# Altium schematic sheet SW6208+A+A+B+LED_普通188数码管.SchDoc
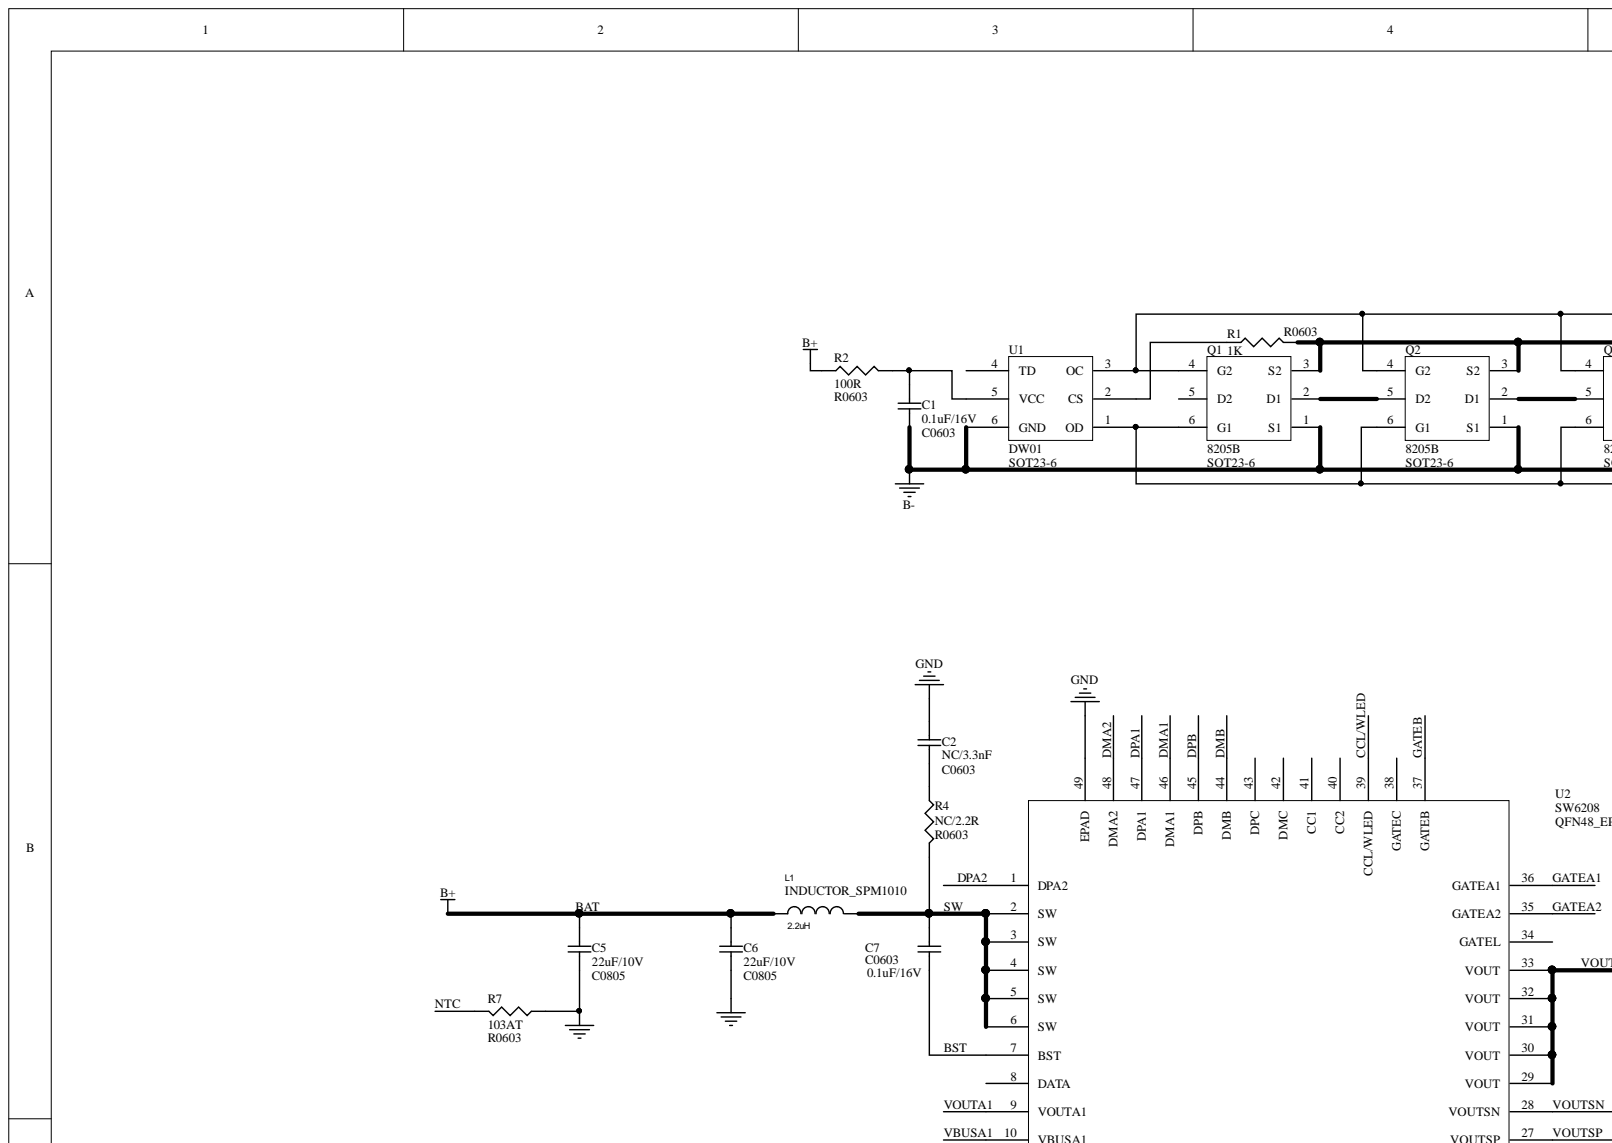
[diagram p0: SW6208+A+A+B+LED_普通188数码管.SchDoc, top left]
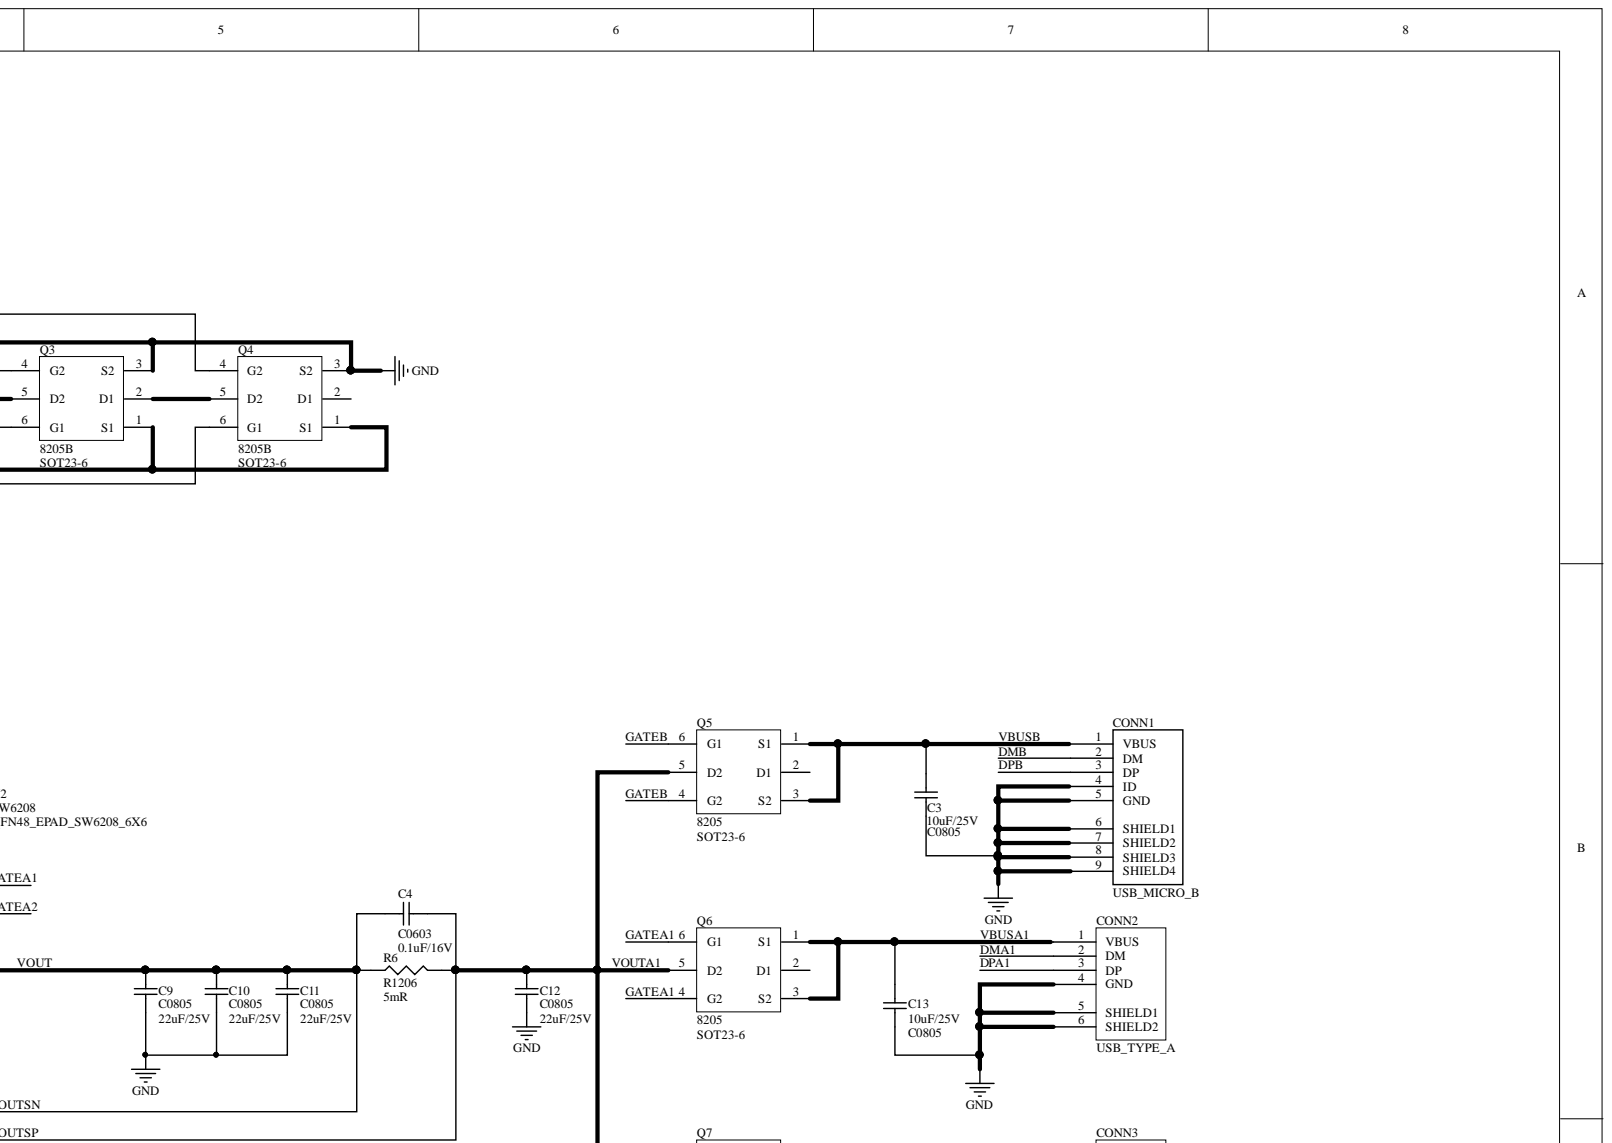
[diagram p1: SW6208+A+A+B+LED_普通188数码管.SchDoc, top right]
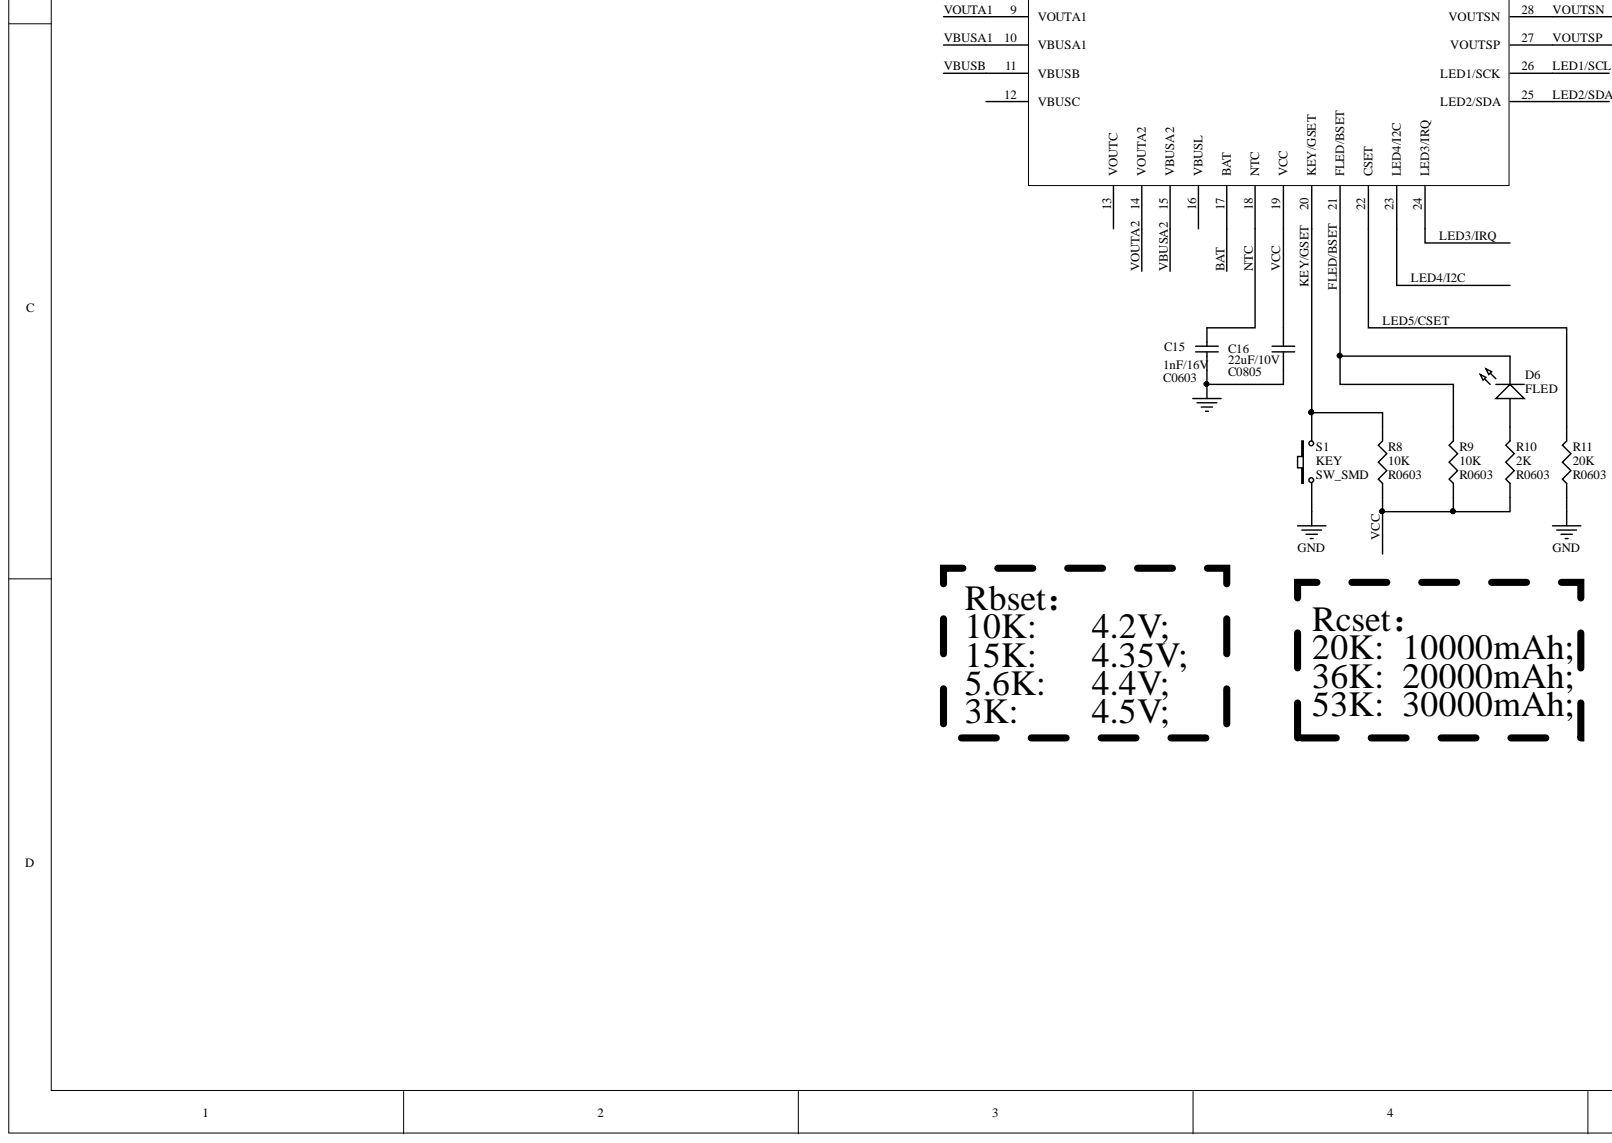
[diagram p2: SW6208+A+A+B+LED_普通188数码管.SchDoc, bottom left]
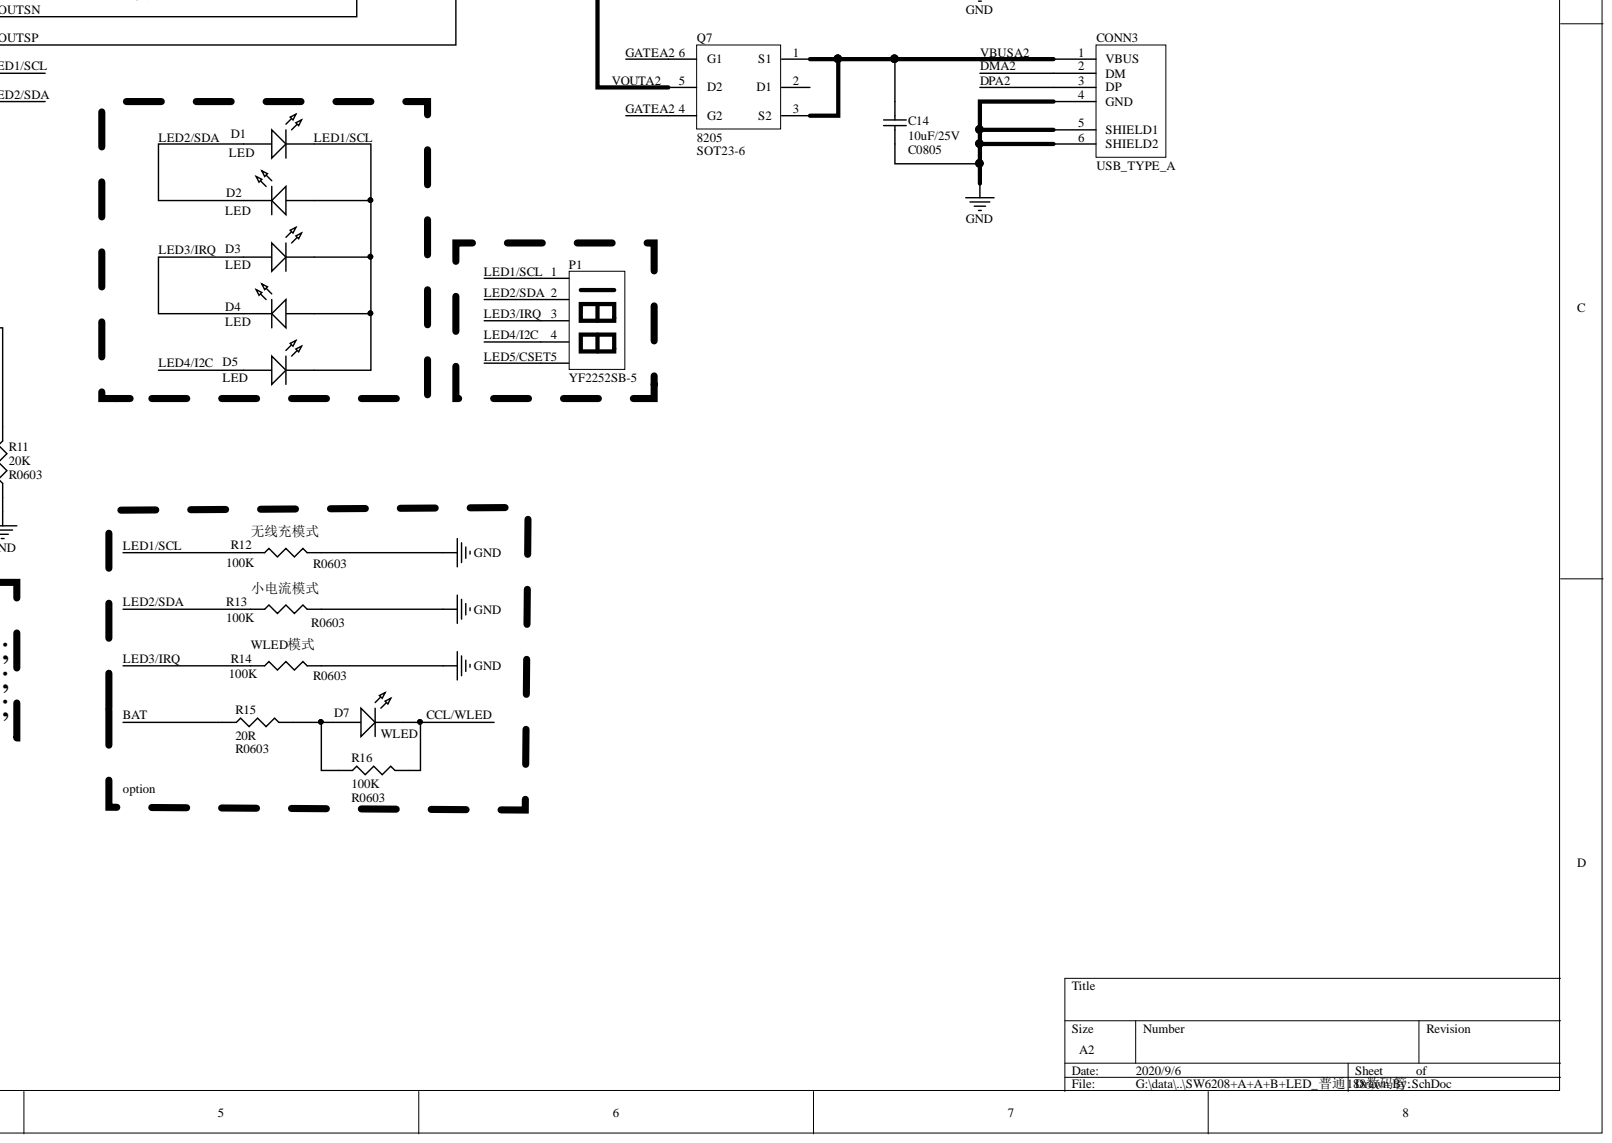
[diagram p3: SW6208+A+A+B+LED_普通188数码管.SchDoc, bottom right]

## Parts
C1  0.1uF/16V  [C0603]
C2  NC/3.3nF  [C0603]
C3  10uF/25V  [C0805]
C4  C0603  value 0.1uF/16V  [C0603]
C5  22uF/10V  [C0805]
C6  22uF/10V  [C0805]
C7  0.1uF/16V  [C0603]
C9  C0805  value 22uF/25V  [C0805]
C10  C0805  value 22uF/25V  [C0805]
C11  C0805  value 22uF/25V  [C0805]
C12  C0805  value 22uF/25V  [C0805]
C13  10uF/25V  [C0805]
C14  10uF/25V  [C0805]
C15  1nF/16V  [C0603]
C16  22uF/10V  [C0805]
CONN1  USB_MICRO_B  [USB_MICRO_B]
CONN2  USB_TYPE_A  [USB_TYPE_A]
CONN3  USB_TYPE_A  [USB_TYPE_A]
D1  LED  [LED0603]
D2  LED  [LED0603]
D3  LED  [LED0603]
D4  LED  [LED0603]
D5  LED  [LED0603]
D6  FLED  [LED0603]
D7  WLED  [LED_5MM]
L1  2.2uH  [INDUCTOR_SPM1010]
P1  YF2252SB-5  [LED_Display_5]
Q1  8205B  [SOT23-6]
Q2  8205B  [SOT23-6]
Q3  8205B  [SOT23-6]
Q4  8205B  [SOT23-6]
Q5  8205  [SOT23-6]
Q6  8205  [SOT23-6]
Q7  8205  [SOT23-6]
R1  1K  [R0603]
R2  100R  [R0603]
R4  NC/2.2R  [R0603]
R6  R1206  value 5mR  [1206]
R7  103AT  [R0603]
R8  10K  [R0603]
R9  10K  [R0603]
R10  2K  [R0603]
R11  20K  [R0603]
R12  100K  [R0603]
R13  100K  [R0603]
R14  100K  [R0603]
R15  20R  [R0603]
R16  100K  [R0603]
S1  KEY  [SW_SMD]
U1  DW01  [SOT23-6]
U2  SW6208  [QFN48_EPAD_SW6208_6X6]

## Nets
B-: C1.1 Q1.1(S1) Q2.1(S1) Q3.1(S1) Q4.1(S1) U1.6(GND)
GND: C2.2 C3.1 C5.1 C6.1 C9.1 C10.1 C11.1 C12.1 C13.1 C14.1 C15.2 C16.2 CONN1.9(SHIELD4) CONN2.4(GND) CONN2.5(SHIELD1) CONN2.6(SHIELD2) CONN3.4(GND) CONN3.5(SHIELD1) CONN3.6(SHIELD2) Q1.3(S2) Q2.3(S2) Q3.3(S2) Q4.3(S2) R1.2 R7.1 R11.1 R12.2 R13.2 R14.2 S1.1 U2.49(EPAD)
BAT: C5.2 C6.2 L1.1 R2.1 R15.1 U2.17(BAT)
BST: C7.1 U2.7(BST)
CCL/WLED: D7.2 R16.2 U2.39(CCL/WLED)
DMA1: CONN2.2(DM) U2.46(DMA1)
DMA2: CONN3.2(DM) U2.48(DMA2)
DMB: CONN1.2(DM) U2.44(DMB)
DPA1: CONN2.3(DP) U2.47(DPA1)
DPA2: CONN3.3(DP) U2.1(DPA2)
DPB: CONN1.3(DP) U2.45(DPB)
FLED/BSET: D6.2 R9.2 U2.21(FLED/BSET)
GATEA1: Q6.4(G2) Q6.6(G1) U2.36(GATEA1)
GATEA2: Q7.4(G2) Q7.6(G1) U2.35(GATEA2)
GATEB: Q5.4(G2) Q5.6(G1) U2.37(GATEB)
KEY/GSET: R8.2 S1.2 U2.20(KEY/GSET)
LED1/SCL: D1.2 D2.1 D3.2 D4.1 D5.2 P1.1 R12.1 U2.26(LED1/SCK)
LED2/SDA: D1.1 D2.2 P1.2 R13.1 U2.25(LED2/SDA)
LED3/IRQ: D3.1 D4.2 P1.3 R14.1 U2.24(LED3/IRQ)
LED4/I2C: D5.1 P1.4 U2.23(LED4/I2C)
LED5/CSET: P1.5 R11.2 U2.22(CSET)
NTC: C15.1 R7.2 U2.18(NTC)
SW: C7.2 L1.2 R4.2 U2.2(SW) U2.3(SW) U2.4(SW) U2.5(SW) U2.6(SW)
VBUSA1: C13.2 CONN2.1(VBUS) Q6.1(S1) Q6.3(S2) U2.10(VBUSA1)
VBUSA2: C14.2 CONN3.1(VBUS) Q7.1(S1) Q7.3(S2) U2.15(VBUSA2)
VBUSB: C3.2 Q5.1(S1) Q5.3(S2) U2.11(VBUSB)
VCC: C16.1 R8.1 R9.1 R10.1 U2.19(VCC)
VOUT: C4.1 C9.2 C10.2 C11.2 R6.1 U2.28(VOUTSN) U2.29(VOUT) U2.30(VOUT) U2.31(VOUT) U2.32(VOUT) U2.33(VOUT)
VOUTA1: C4.2 C12.2 Q5.5(D2) Q6.5(D2) Q7.5(D2) R6.2 U2.9(VOUTA1) U2.14(VOUTA2) U2.27(VOUTSP)
NetC1_2: C1.2 R2.2 U1.5(VCC)
NetC2_1: C2.1 R4.1
NetD6_1: D6.1 R10.2
NetD7_1: D7.1 R15.2 R16.1
NetQ1_2: Q1.2(D1) Q2.5(D2)
NetQ1_4: Q1.4(G2) Q2.4(G2) Q3.4(G2) Q4.4(G2) U1.3(OC)
NetQ1_6: Q1.6(G1) Q2.6(G1) Q3.6(G1) Q4.6(G1) U1.1(OD)
NetQ2_2: Q2.2(D1) Q3.5(D2)
NetQ3_2: Q3.2(D1) Q4.5(D2)
NetR1_1: R1.1 U1.2(CS)

Not connected: CONN1 1(VBUS) 4(ID) 5(GND) 6(SHIELD1) 7(SHIELD2) 8(SHIELD3); Q1 5(D2); Q4 2(D1); Q5 2(D1); Q6 2(D1); Q7 2(D1); U1 4(TD); U2 8(DATA) 12(VBUSC) 13(VOUTC) 16(VBUSL) 34(GATEL) 38(GATEC) 40(CC2) 41(CC1) 42(DMC) 43(DPC)

## Library data of the parts
C1 C2 C7 C15 = CAP0603: Capacitor; Value: 22uF/16V; Component Kind: Standard; LatestRevisionDate: 17-Jul-2002; LatestRevisionNote: Re-released for DXP Platform.; PackageDescription: Radial Cap, Thru-Hole; 2 Leads; 0.3 in Pin Spacing; PackageReference: RAD-0.3; Published: 8-Jun-2000; Library Name: 参考设计器件原理图更新.SchLib; Publisher: Altium Limited
C3 C5 C6 C13 C14 C16 = CAP0805: Capacitor; Value: 22uF/25V; Component Kind: Standard; LatestRevisionDate: 17-Jul-2002; LatestRevisionNote: Re-released for DXP Platform.; PackageDescription: Radial Cap, Thru-Hole; 2 Leads; 0.3 in Pin Spacing; PackageReference: RAD-0.3; Published: 8-Jun-2000; Library Name: 参考设计器件原理图更新.SchLib; Publisher: Altium Limited
C4 = CAP0603: Capacitor; Value: 0.1uF/16V; Component Kind: Standard; LatestRevisionDate: 17-Jul-2002; LatestRevisionNote: Re-released for DXP Platform.; PackageDescription: Radial Cap, Thru-Hole; 2 Leads; 0.3 in Pin Spacing; PackageReference: RAD-0.3; Published: 8-Jun-2000; Publisher: Altium Limited
C9-C12 = CAP0805: Capacitor; Component Kind: Standard; LatestRevisionDate: 17-Jul-2002; LatestRevisionNote: Re-released for DXP Platform.; PackageDescription: Radial Cap, Thru-Hole; 2 Leads; 0.3 in Pin Spacing; PackageReference: RAD-0.3; Published: 8-Jun-2000; Publisher: Altium Limited
D1-D5 = LED_0603: Value: FLED; Component Kind: Standard; Description: LED; LatestRevisionDate: 08-Jul-2005; LatestRevisionNote: Mech Layer 1 removed.; PackageDescription: LED; 2 Leads; PackageReference: LED-0; Published: 8-Jun-2000; Library Name: 参考设计器件原理图更新.SchLib; Publisher: Altium Limited
D6 = LED_0603: LED; Component Kind: Standard; LatestRevisionDate: 08-Jul-2005; LatestRevisionNote: Mech Layer 1 removed.; PackageDescription: LED; 2 Leads; PackageReference: LED-0; Published: 8-Jun-2000; Value: FLED; Library Name: 参考设计器件原理图更新.SchLib; Publisher: Altium Limited
D7 = WLED: Value: FLED; Component Kind: Standard; Description: WLED; LatestRevisionDate: 08-Jul-2005; LatestRevisionNote: Mech Layer 1 removed.; PackageDescription: LED; 2 Leads; PackageReference: LED-0; Published: 8-Jun-2000; Library Name: 参考设计器件原理图更新.SchLib; Publisher: Altium Limited
L1 = INDUCTOR_SPM1010: INDUCTOR; Component Kind: Standard; Value: 2.2uH; Library Name: 参考设计器件原理图更新.SchLib
P1 = LED_Display_5: 188_LED_Display; Component Kind: Standard; Library Name: 参考设计器件原理图更新.SchLib
Q1-Q4 = 8205: NMOS; Value: 8205; Component Kind: Standard; Footprinf: SOT23-6; Library Name: 参考设计器件原理图更新.SchLib
Q5-Q7 = 8205: NMOS; Component Kind: Standard; Footprinf: SOT23-6; Value: 8205; Library Name: 参考设计器件原理图更新.SchLib
R1 R2 R4 R7 R10-R16 = RES_0603: Resistor; Value: 10K; Component Kind: Standard; LatestRevisionDate: 29-May-2009; LatestRevisionNote: IPC-7351 Footprint Added.; PackageDescription: Chip Resistor; PackageReference: J1-0603; Published: 8-Jun-2000; Library Name: 参考设计器件原理图更新.SchLib; Publisher: Altium Limited
R6 = RES: Resistor; Component Kind: Standard; LatestRevisionDate: 29-May-2009; LatestRevisionNote: IPC-7351 Footprint Added.; PackageDescription: Chip Resistor; PackageReference: J1-0603; Published: 8-Jun-2000; Publisher: Altium Limited
R8 R9 = RES_0603: Resistor; Component Kind: Standard; LatestRevisionDate: 29-May-2009; LatestRevisionNote: IPC-7351 Footprint Added.; PackageDescription: Chip Resistor; PackageReference: J1-0603; Published: 8-Jun-2000; Value: 10K; Library Name: 参考设计器件原理图更新.SchLib; Publisher: Altium Limited
S1 = SW K: Component Kind: Standard; Description: KEY; Footprinf: SW_SMD; Value: KEY; Library Name: 参考设计器件原理图更新.SchLib
U1 = DW01: BAT_PROTECT_IC; Component Kind: Standard; Value: DW01; Library Name: 参考设计器件原理图更新.SchLib
U2 = SW6208: IC; Component Kind: Standard; Library Name: 芯片型号原理图.SchLib
CONN1 = USB_MICRO_B: Component Kind: Standard; Description: USB_MICRO_B; Footprintf: MICRO_B; Value: USB_MICRO_B; Library Name: 参考设计器件原理图更新.SchLib
CONN2 CONN3 = USB_TYPE_A: Component Kind: Standard; Description: USB_TYPE_A; Library Name: 参考设计器件原理图更新.SchLib
